# Altium schematic sheet P03_MCU.SchDoc
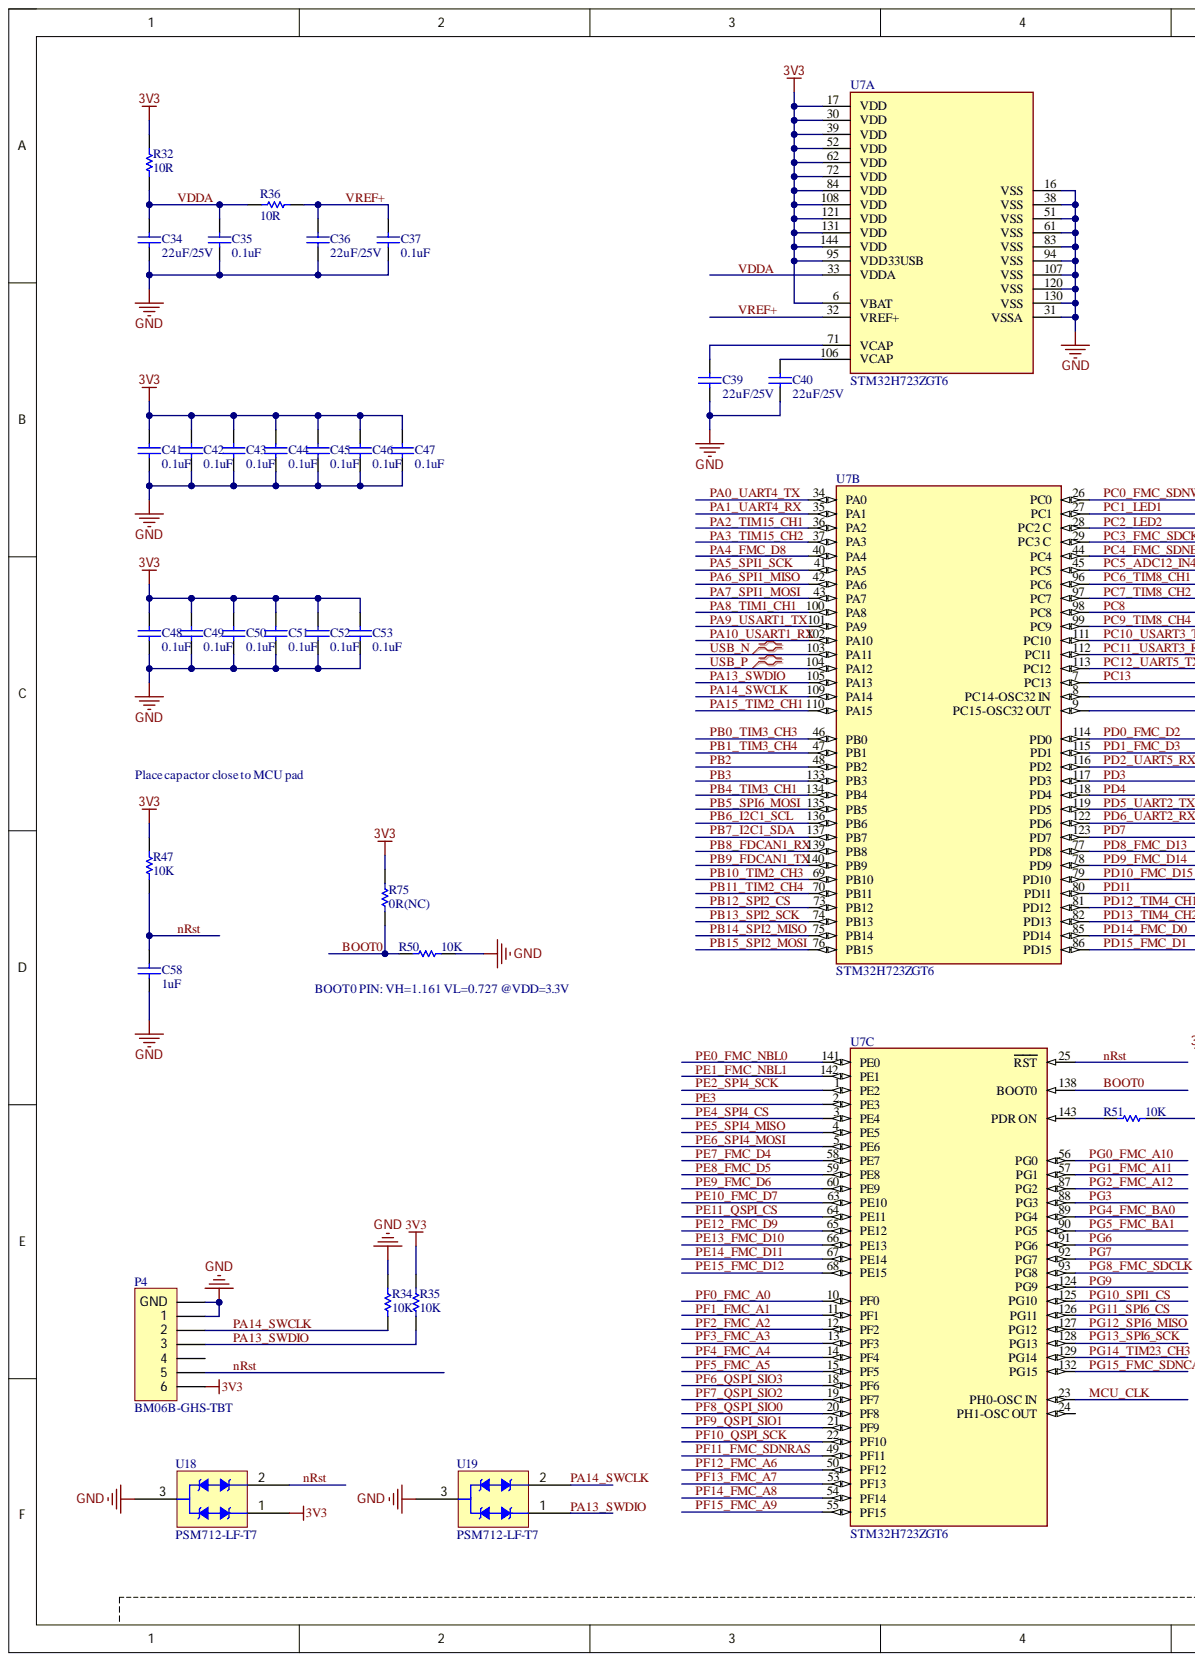
[diagram p0: P03_MCU.SchDoc, left]
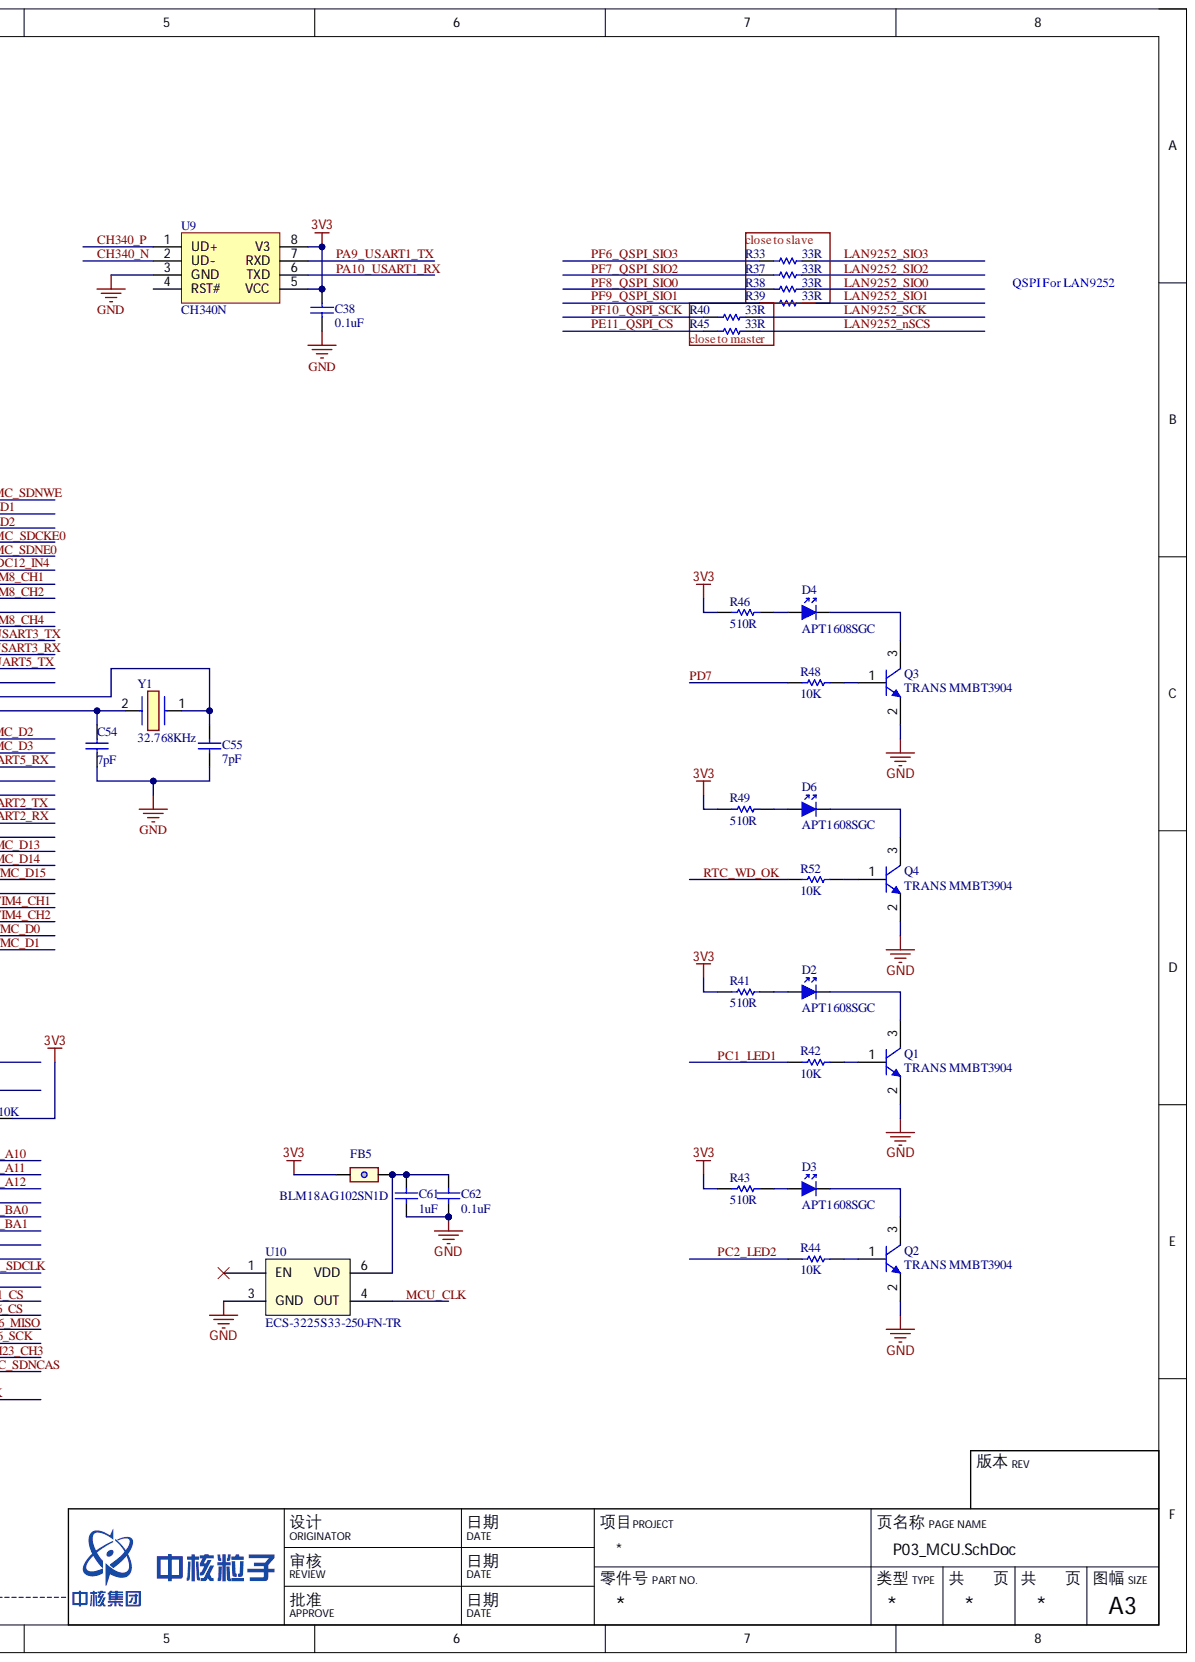
[diagram p1: P03_MCU.SchDoc, right]

## Parts
C34  22uF/25V  [C1206(3216)]
C35  0.1uF  [C0603(1608)]
C36  22uF/25V  [C1206(3216)]
C37  0.1uF  [C0603(1608)]
C38  0.1uF  [C0603(1608)]
C39  22uF/25V  [C1206(3216)]
C40  22uF/25V  [C1206(3216)]
C41  0.1uF  [C0603(1608)]
C42  0.1uF  [C0603(1608)]
C43  0.1uF  [C0603(1608)]
C44  0.1uF  [C0603(1608)]
C45  0.1uF  [C0603(1608)]
C46  0.1uF  [C0603(1608)]
C47  0.1uF  [C0603(1608)]
C48  0.1uF  [C0603(1608)]
C49  0.1uF  [C0603(1608)]
C50  0.1uF  [C0603(1608)]
C51  0.1uF  [C0603(1608)]
C52  0.1uF  [C0603(1608)]
C53  0.1uF  [C0603(1608)]
C54  7pF  [C0603(1608)]
C55  7pF  [C0603(1608)]
C58  1uF  [C0603(1608)]
C61  1uF  [C0603(1608)]
C62  0.1uF  [C0603(1608)]
D2  APT1608SGC  [LED0603O]
D3  APT1608SGC  [LED0603O]
D4  APT1608SGC  [LED0603O]
D6  APT1608SGC  [LED0603O]
FB5  BLM18AG102SN1D  [R0603(1608)]
P4  BM06B-GHS-TBT  [BM06B-GHS-TBT]
Q1  TRANS MMBT3904  [SOT-23-3]
Q2  TRANS MMBT3904  [SOT-23-3]
Q3  TRANS MMBT3904  [SOT-23-3]
Q4  TRANS MMBT3904  [SOT-23-3]
R32  10R  [R0603(1608)]
R33  33R  [R0603(1608)]
R34  10K  [R0603(1608)]
R35  10K  [R0603(1608)]
R36  10R  [R0603(1608)]
R37  33R  [R0603(1608)]
R38  33R  [R0603(1608)]
R39  33R  [R0603(1608)]
R40  33R  [R0603(1608)]
R41  510R  [R0603(1608)]
R42  10K  [R0603(1608)]
R43  510R  [R0603(1608)]
R44  10K  [R0603(1608)]
R45  33R  [R0603(1608)]
R46  510R  [R0603(1608)]
R47  10K  [R0603(1608)]
R48  10K  [R0603(1608)]
R49  510R  [R0603(1608)]
R50  10K  [R0603(1608)]
R51  10K  [R0603(1608)]
R52  10K  [R0603(1608)]
R75  0R(NC)  [R0603(1608)]
U7  STM32H723ZGT6  [LQFP144-1A-IPC_A]  (parts A,B,C)
U9  CH340N  [SOIC8]
U10  ECS-3225S33-250-FN-TR  [ECS-3225S33-250-FN-TR]
U18  PSM712-LF-T7  [SOT-23(SOT-23-3)]
U19  PSM712-LF-T7  [SOT-23(SOT-23-3)]
Y1  32.768KHz  [SC32S7PF20PPM]

## Nets
3V3: C38.2 C41.2 C42.2 C43.2 C44.2 C45.2 C46.2 C47.2 C48.2 C49.2 C50.2 C51.2 C52.2 C53.2 FB5.1 P4.6 R32.2 R35.1 R41.2 R43.2 R46.2 R47.1 R49.2 R51.2 R75.2 U7.6(VBAT) U7.17(VDD) U7.30(VDD) U7.39(VDD) U7.52(VDD) U7.62(VDD) U7.72(VDD) U7.84(VDD) U7.95(VDD33USB) U7.108(VDD) U7.121(VDD) U7.131(VDD) U7.144(VDD) U9.5(VCC) U9.8(V3) U18.1
GND: C34.1 C35.1 C36.1 C37.1 C38.1 C39.1 C40.1 C41.1 C42.1 C43.1 C44.1 C45.1 C46.1 C47.1 C48.1 C49.1 C50.1 C51.1 C52.1 C53.1 C54.1 C55.1 C58.1 C61.1 C62.1 P4.1 P4.GND Q1.2 Q2.2 Q3.2 Q4.2 R34.1 R50.2 U7.16(VSS) U7.31(VSSA) U7.38(VSS) U7.51(VSS) U7.61(VSS) U7.83(VSS) U7.94(VSS) U7.107(VSS) U7.120(VSS) U7.130(VSS) U9.3(GND) U10.3(GND) U18.3 U19.3
BOOT0: R50.1 R75.1 U7.138(BOOT0)
CH340_N: U9.2(UD-)
CH340_P: U9.1(UD+)
LAN9252_nSCS: R45.2
LAN9252_SCK: R40.2
LAN9252_SIO0: R38.2
LAN9252_SIO1: R39.2
LAN9252_SIO2: R37.2
LAN9252_SIO3: R33.2
MCU_CLK: U7.23(PH0-OSC_IN) U10.4(OUT)
nRst: C58.2 P4.5 R47.2 U7.25(RST) U18.2
PA0_UART4_TX: U7.34(PA0)
PA1_UART4_RX: U7.35(PA1)
PA2_TIM15_CH1: U7.36(PA2)
PA3_TIM15_CH2: U7.37(PA3)
PA4_FMC_D8: U7.40(PA4)
PA5_SPI1_SCK: U7.41(PA5)
PA6_SPI1_MISO: U7.42(PA6)
PA7_SPI1_MOSI: U7.43(PA7)
PA8_TIM1_CH1: U7.100(PA8)
PA9_USART1_TX: U7.101(PA9) U9.7(RXD)
PA10_USART1_RX: U7.102(PA10) U9.6(TXD)
PA13_SWDIO: P4.3 R35.2 U7.105(PA13) U19.1
PA14_SWCLK: P4.2 R34.2 U7.109(PA14) U19.2
PA15_TIM2_CH1: U7.110(PA15)
PB0_TIM3_CH3: U7.46(PB0)
PB1_TIM3_CH4: U7.47(PB1)
PB2: U7.48(PB2)
PB3: U7.133(PB3)
PB4_TIM3_CH1: U7.134(PB4)
PB5_SPI6_MOSI: U7.135(PB5)
PB6_I2C1_SCL: U7.136(PB6)
PB7_I2C1_SDA: U7.137(PB7)
PB8_FDCAN1_RX: U7.139(PB8)
PB9_FDCAN1_TX: U7.140(PB9)
PB10_TIM2_CH3: U7.69(PB10)
PB11_TIM2_CH4: U7.70(PB11)
PB12_SPI2_CS: U7.73(PB12)
PB13_SPI2_SCK: U7.74(PB13)
PB14_SPI2_MISO: U7.75(PB14)
PB15_SPI2_MOSI: U7.76(PB15)
PC0_FMC_SDNWE: U7.26(PC0)
PC1_LED1: R42.2 U7.27(PC1)
PC2_LED2: R44.2 U7.28(PC2_C)
PC3_FMC_SDCKE0: U7.29(PC3_C)
PC4_FMC_SDNE0: U7.44(PC4)
PC5_ADC12_IN4: U7.45(PC5)
PC6_TIM8_CH1: U7.96(PC6)
PC7_TIM8_CH2: U7.97(PC7)
PC8: U7.98(PC8)
PC9_TIM8_CH4: U7.99(PC9)
PC10_USART3_TX: U7.111(PC10)
PC11_USART3_RX: U7.112(PC11)
PC12_UART5_TX: U7.113(PC12)
PC13: U7.7(PC13)
PD0_FMC_D2: U7.114(PD0)
PD1_FMC_D3: U7.115(PD1)
PD2_UART5_RX: U7.116(PD2)
PD3: U7.117(PD3)
PD4: U7.118(PD4)
PD5_UART2_TX: U7.119(PD5)
PD6_UART2_RX: U7.122(PD6)
PD7: R48.2 U7.123(PD7)
PD8_FMC_D13: U7.77(PD8)
PD9_FMC_D14: U7.78(PD9)
PD10_FMC_D15: U7.79(PD10)
PD11: U7.80(PD11)
PD12_TIM4_CH1: U7.81(PD12)
PD13_TIM4_CH2: U7.82(PD13)
PD14_FMC_D0: U7.85(PD14)
PD15_FMC_D1: U7.86(PD15)
PE0_FMC_NBL0: U7.141(PE0)
PE1_FMC_NBL1: U7.142(PE1)
PE2_SPI4_SCK: U7.1(PE2)
PE3: U7.2(PE3)
PE4_SPI4_CS: U7.3(PE4)
PE5_SPI4_MISO: U7.4(PE5)
PE6_SPI4_MOSI: U7.5(PE6)
PE7_FMC_D4: U7.58(PE7)
PE8_FMC_D5: U7.59(PE8)
PE9_FMC_D6: U7.60(PE9)
PE10_FMC_D7: U7.63(PE10)
PE11_QSPI_CS: R45.1 U7.64(PE11)
PE12_FMC_D9: U7.65(PE12)
PE13_FMC_D10: U7.66(PE13)
PE14_FMC_D11: U7.67(PE14)
PE15_FMC_D12: U7.68(PE15)
PF0_FMC_A0: U7.10(PF0)
PF1_FMC_A1: U7.11(PF1)
PF2_FMC_A2: U7.12(PF2)
PF3_FMC_A3: U7.13(PF3)
PF4_FMC_A4: U7.14(PF4)
PF5_FMC_A5: U7.15(PF5)
PF6_QSPI_SIO3: R33.1 U7.18(PF6)
PF7_QSPI_SIO2: R37.1 U7.19(PF7)
PF8_QSPI_SIO0: R38.1 U7.20(PF8)
PF9_QSPI_SIO1: R39.1 U7.21(PF9)
PF10_QSPI_SCK: R40.1 U7.22(PF10)
PF11_FMC_SDNRAS: U7.49(PF11)
PF12_FMC_A6: U7.50(PF12)
PF13_FMC_A7: U7.53(PF13)
PF14_FMC_A8: U7.54(PF14)
PF15_FMC_A9: U7.55(PF15)
PG0_FMC_A10: U7.56(PG0)
PG1_FMC_A11: U7.57(PG1)
PG2_FMC_A12: U7.87(PG2)
PG3: U7.88(PG3)
PG4_FMC_BA0: U7.89(PG4)
PG5_FMC_BA1: U7.90(PG5)
PG6: U7.91(PG6)
PG7: U7.92(PG7)
PG8_FMC_SDCLK: U7.93(PG8)
PG9: U7.124(PG9)
PG10_SPI1_CS: U7.125(PG10)
PG11_SPI6_CS: U7.126(PG11)
PG12_SPI6_MISO: U7.127(PG12)
PG13_SPI6_SCK: U7.128(PG13)
PG14_TIM23_CH3: U7.129(PG14)
PG15_FMC_SDNCAS: U7.132(PG15)
RTC_WD_OK: R52.2
USB_N: U7.103(PA11)
USB_P: U7.104(PA12)
VDDA: C34.2 C35.2 R32.1 R36.2 U7.33(VDDA)
VREF+: C36.2 C37.2 R36.1 U7.32(VREF+)
NetC39_2: C39.2 U7.71(VCAP)
NetC40_2: C40.2 U7.106(VCAP)
NetC54_2: C54.2 U7.9(PC15-OSC32_OUT) Y1.2
NetC55_2: C55.2 U7.8(PC14-OSC32_IN) Y1.1
NetC61_2: C61.2 C62.2 FB5.2 U10.6(VDD)
NetD2_1: D2.1 R41.1
NetD2_2: D2.2 Q1.3
NetD3_1: D3.1 R43.1
NetD3_2: D3.2 Q2.3
NetD4_1: D4.1 R46.1
NetD4_2: D4.2 Q3.3
NetD6_1: D6.1 R49.1
NetD6_2: D6.2 Q4.3
NetQ1_1: Q1.1 R42.1
NetQ2_1: Q2.1 R44.1
NetQ3_1: Q3.1 R48.1
NetQ4_1: Q4.1 R52.1
NetR51_1: R51.1 U7.143(PDR_ON)

Not connected: P4 4; U7 24(PH1-OSC_OUT); U9 4(RST#); U10 1(EN)

## Library data of the parts
C34 C36 C39 C40 = Cap 22uF 1206-25V: 1206 [3216 公制], 22 µF, 25 V, ± 10%, X5R, GRM系列; 价格: ¥2.84@10; 制造商: MURATA; 名称: 瓷片电容; 型号: GRM31CR61E226KE15L; Component Kind: Standard; Library Name: RCL.SchLib; 供应商及库存编号: e络盟 1797010
C35 C37 C38 C41-C53 = Cap 0.1uF 0603: ceramic 25V; 价格: ￥0.092@100; 制造商: KEMET; 型号: C0603C104K3RACTU; Component Kind: Standard; Library Name: RCL.SchLib; 供应商及库存编号: e络盟 1650835
C54 C55 = Cap 7pF 0603-50V C0G: ceramic 50V C0G 5%; 制造商: muRata; 型号: GRM1885C1H7R0CA01D; Component Kind: Standard; Library Name: RCL.SchLib
C58 = Cap 1uF 0603-50V: ceramic 50V; 价格: ¥1.96@100; 制造商: TDK; 型号: CGA3E3X5R1H105K080AB; Component Kind: Standard; Library Name: RCL.SchLib; 供应商及库存编号: e络盟 2210922
C61 = Cap 1uF 0603-1: ceramic 10V; 价格: ¥0.11@100; 制造商: KEMET; 型号: C0603C105K8PACTU; Component Kind: Standard; Library Name: RCL.SchLib; 供应商及库存编号: e络盟 9227776
C62 = Cap 0.1uF 0603: ceramic 25V; 价格: ¥0.092@100; 制造商: KEMET; 型号: C0603C104K3RACTU; Component Kind: Standard; Library Name: RCL.SchLib; 供应商及库存编号: e络盟 1650835
D2-D4 D6 = LED APT1608SGC: RUNLED, Green, 0603; 价格: $0.036@100; 制造商: Kingbright; Component Kind: Standard; Library Name: RCL.SchLib; 供应商及库存编号: digikey 754-1121-1-ND; 型号: APT1608SGC
FB5 = FB-1K@100MHz: 0603; 价格: ¥0.25@100; 制造商: MURATA; Component Kind: Standard; Library Name: RCL.SchLib; 供应商及库存编号: e络盟 1515671; 型号: BLM18AG102SN1D
Q1-Q4 = TRANS MMBT3904: NPN 40V 0.2A SOT23; 价格: ￥0.23@100; 制造商: ON Semiconductor; 型号: MMBT3904LT3G; Component Kind: Standard; Library Name: RCL.SchLib; 供应商及库存编号: e络盟 1287979
R32 R36 = Res 10R 0603: Res ±1%; Component Kind: Standard; Library Name: RCL.SchLib
R33 R37-R40 R45 = Res 33R 0603: Res ±1%; 名称: 贴片电阻; Component Kind: Standard; Library Name: RCL.SchLib
R34 R35 R42 R44 R47 R48 R52 = Res 10K 0603: Res ±1%; Component Kind: Standard; Library Name: RCL.SchLib
R41 R43 R46 R49 = Res 510R 0603: Res ±1%; Component Kind: Standard; Library Name: RCL.SchLib
R50 R51 = Res 10K 0603: Res ±1%; 名称: 贴片电阻; Component Kind: Standard; Library Name: RCL.SchLib
R75 = Res 0R 0603: Res ±1%; Component Kind: Standard; Library Name: RCL.SchLib
U7 = STM32H723ZGT6: IC MCU 32BIT 1MB FLASH 144LQFP; Component Kind: Standard; Library Name: IC2022.SchLib
U9 = CH340N: USB转TTL串口; Component Kind: Standard; Library Name: IC2023.SchLib
U10 = ECS-3225S33-250-FN-TR: OSC XO 25.0000MHZ HCMOS SMD; 价格: $0.755@1K; 制造商: ECS Inc.; Component Kind: Standard; Library Name: IC.SchLib; 供应商及库存编号: digikey XC2221TR-ND; 型号: ECS-3225S33-250-FN-TR
U18 U19 = PSM712-LF-T7: TVS; Component Kind: Standard; ComponentLink1Description: 供应商链接; ComponentLink1URL: http://www.szlcsc.com/product/details_33642.html; Library Name: IC2023.SchLib; Package: SOT-23(SOT-23-3); Supplier: LC; SuppliersPartNumber: C32677
Y1 = XTAL32.768KHz: SC32S-7PF20PPM; Component Kind: Standard; Library Name: IC2022.SchLib
P4 = BM06B-GHS-TBT: Component Kind: Standard; Description: BM06B-GHS-TBT; Library Name: Connector2023.SchLib
